# Altium schematic sheet Power.SchDoc
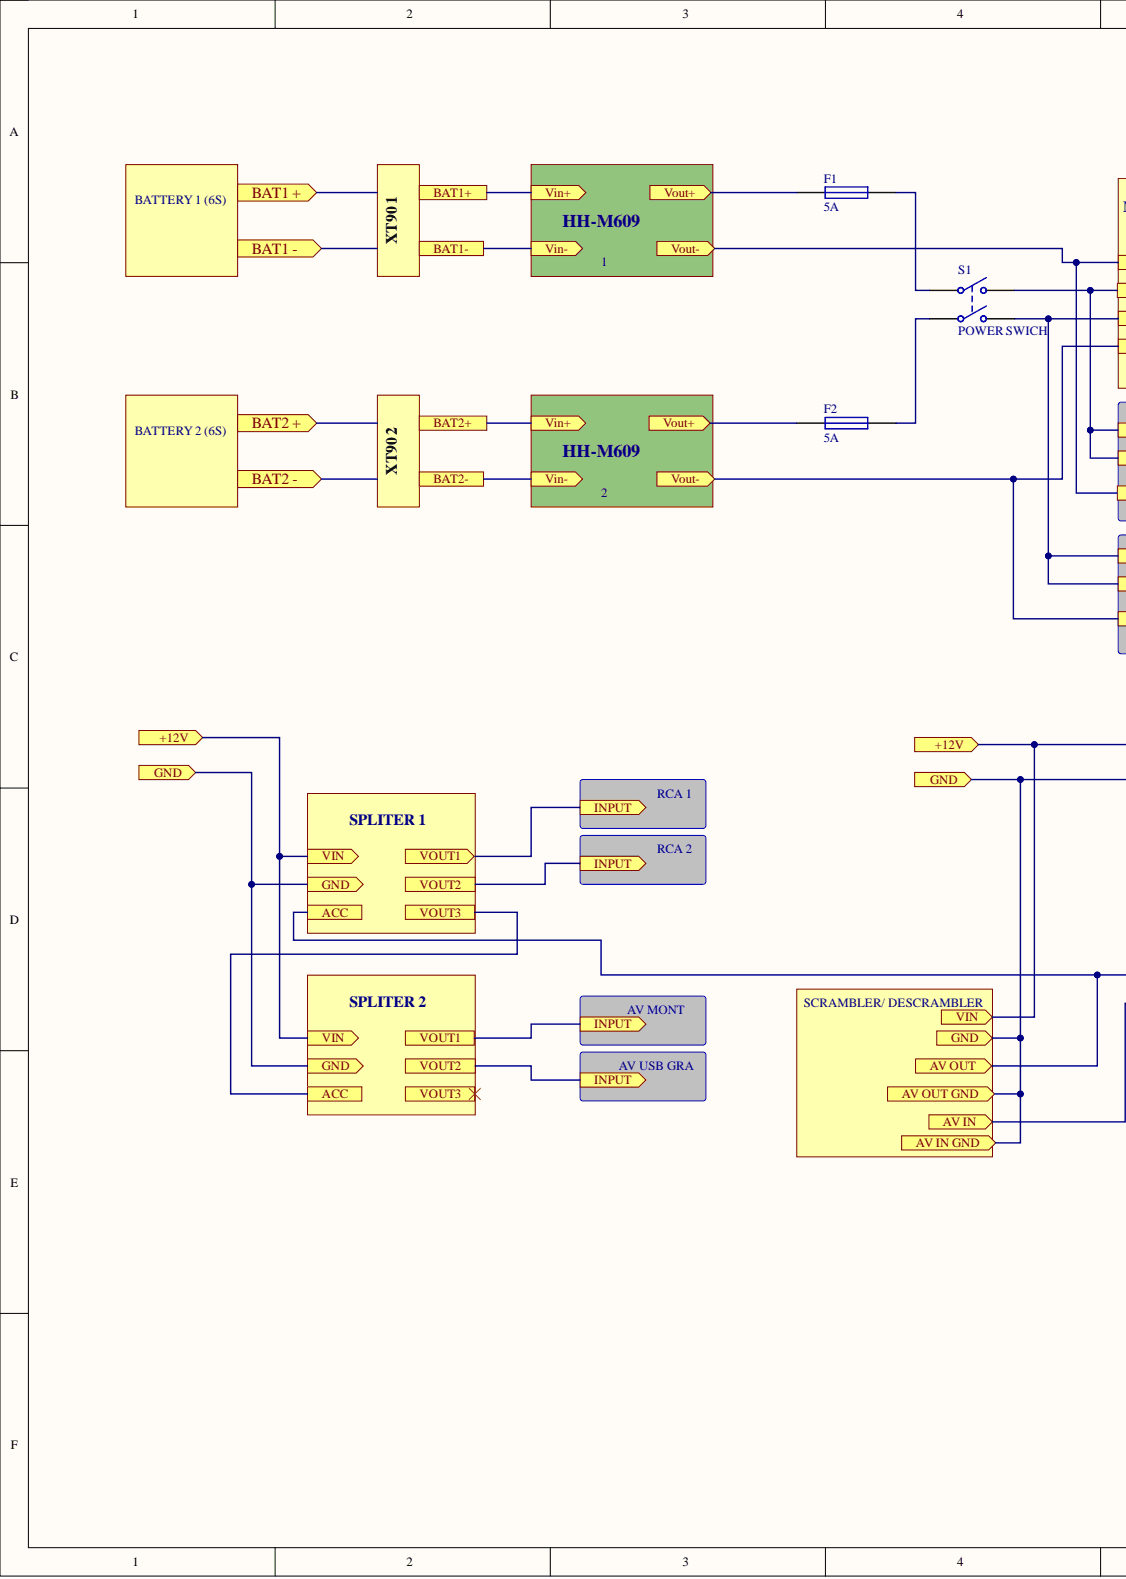
[diagram p0: Power.SchDoc, left]
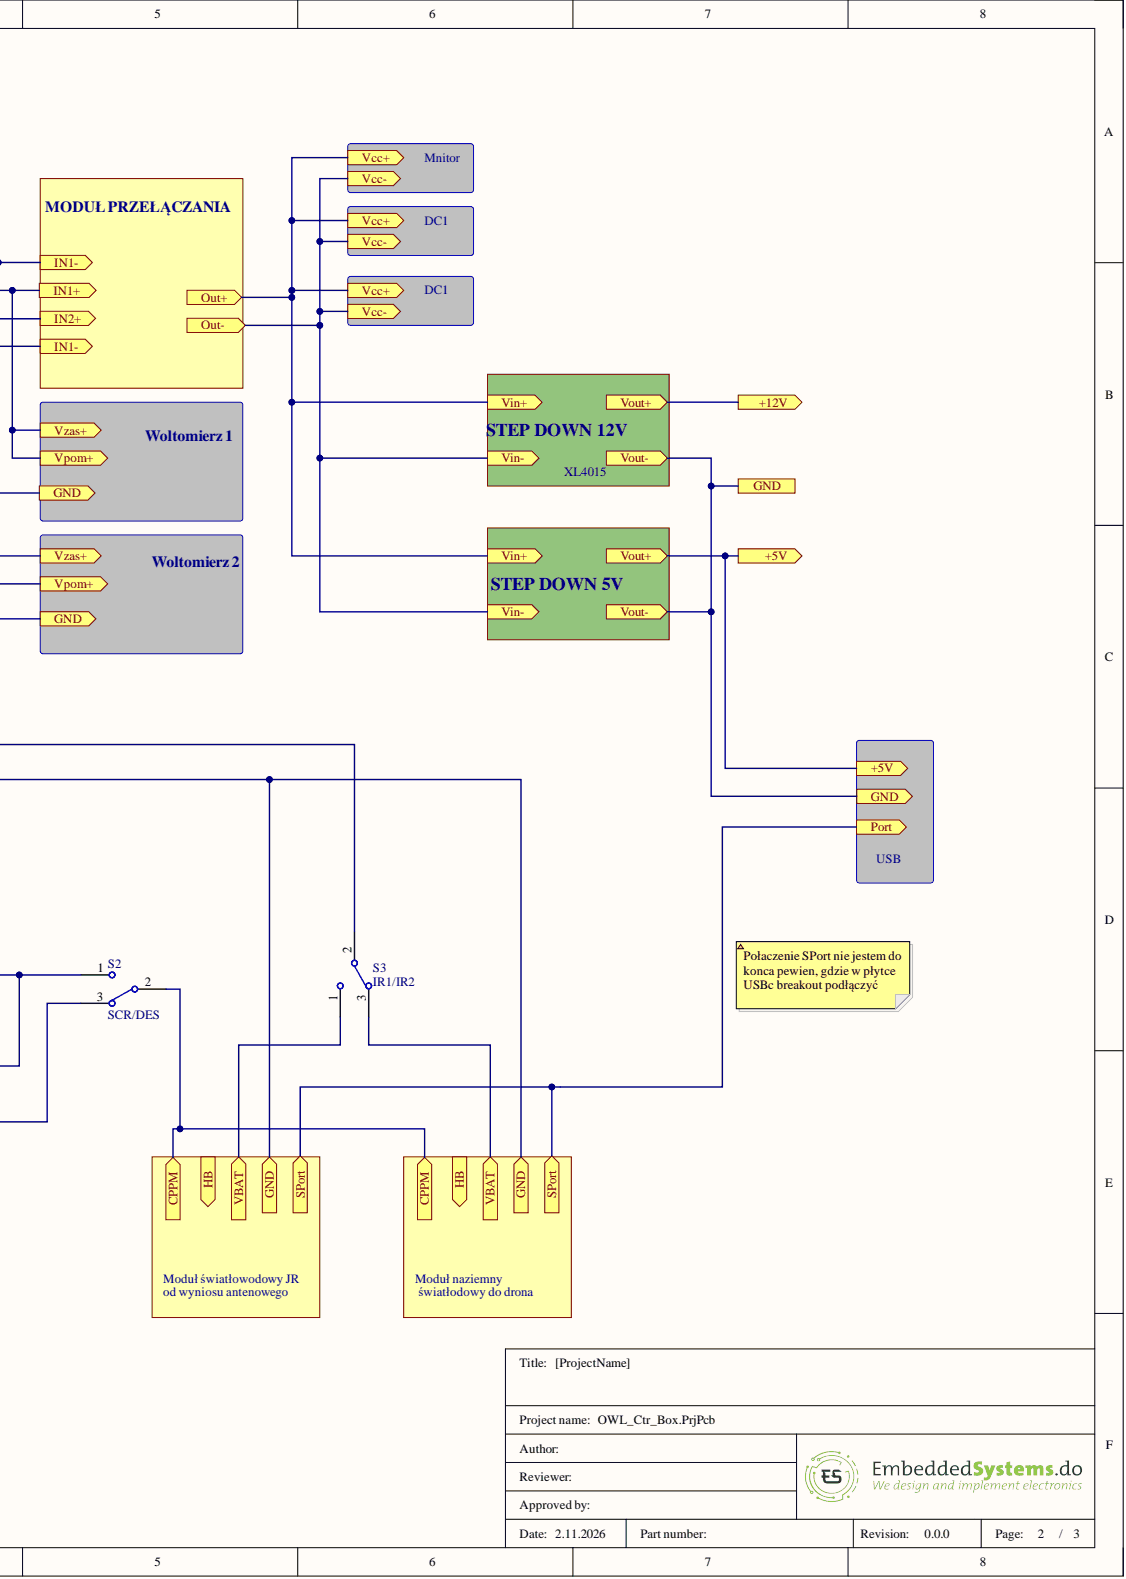
[diagram p1: Power.SchDoc, right]

## Parts
F1  5A  [PIN-W2/E2.8]
F2  5A  [PIN-W2/E2.8]
S1  POWER SWICH  [DPST-4]
S2  SCR/DES  [TL36WW15050]
S3  IR1/IR2  [TL36WW15050]

## Ports
+5V, +12V, ACC, AV IN, AV IN GND, AV OUT, AV OUT GND, BAT1 +, BAT1 -, BAT1+, BAT1-, BAT2 +, BAT2 -, BAT2+, BAT2-, CPPM, GND, HB, IN1+, IN1-, IN2+, INPUT, Out+, Out-, Port, SPort, VBAT, Vcc+, Vcc-, VIN, Vin+, Vin-, VOUT1, VOUT2, VOUT3, Vout+, Vout-, Vpom+, Vzas+

## Nets
+12V: F1.1 F2.1 S3.2 ; port +12V, port +5V, port VIN
ACC: S2.1 ; port ACC, port VOUT3
AV IN: S2.3 ; port AV IN
CPPM: S2.2 ; port CPPM
IN2+: S1.2 S1.4 ; port IN2+, port Vpom+, port Vzas+
VBAT: S3.1 S3.3 ; port VBAT
NetF1_2: F1.2 S1.1
NetF2_2: F2.2 S1.3
N00001:
N00002:
N00003:
N00004:
N00005:
N00006:
N00007: ; port AV IN GND, port AV OUT GND, port GND
N00008: ; port INPUT, port VOUT1, port VOUT2
N00009: ; port Port, port SPort
N00010: ; port AV OUT
N00011: ; port HB

## Library data of the parts
F1 F2 = Fuse 1: Fuse; Component Kind: Standard; LatestRevisionDate: 17-Jul-2002; LatestRevisionNote: Re-released for DXP Platform.; Library Name: Miscellaneous Devices.IntLib; PackageDescription: Thru-Hole; 2 Leads; PackageReference: PIN-W2/E2.8; Published: 8-Jun-2000; Simulation: FUSE; Publisher: Altium Limited
S1 = SW-DPST: Double-Pole, Single-Throw Switch; Component Kind: Standard; LatestRevisionDate: 17-Jul-2002; LatestRevisionNote: Re-released for DXP Platform.; Library Name: Miscellaneous Devices.IntLib; PackageDescription: Switch; 4 Leads; PackageReference: DPST-4; Published: 24-Mar-1999; Publisher: Altium Limited
S2 S3 = SW-SPDT: SPDT Subminiature Toggle Switch, Right Angle Mounting, Vertical Actuation; Component Kind: Standard; ComponentLink1Description: Manufacturer Link; ComponentLink1URL: http://www.apem.com; DatasheetVersion: 05-Dec-2000; LatestRevisionDate: 17-Dec-2003; LatestRevisionNote: Schematic revised, PCB Footprint replaced.; Library Name: Miscellaneous Devices.IntLib; PackageDescription: Switch; 4 Leads; PackageReference: TL36WW15050; Published: 24-Mar-1999; Publisher: Altium Limited
